# Altium schematic sheet Gain Control.SchDoc
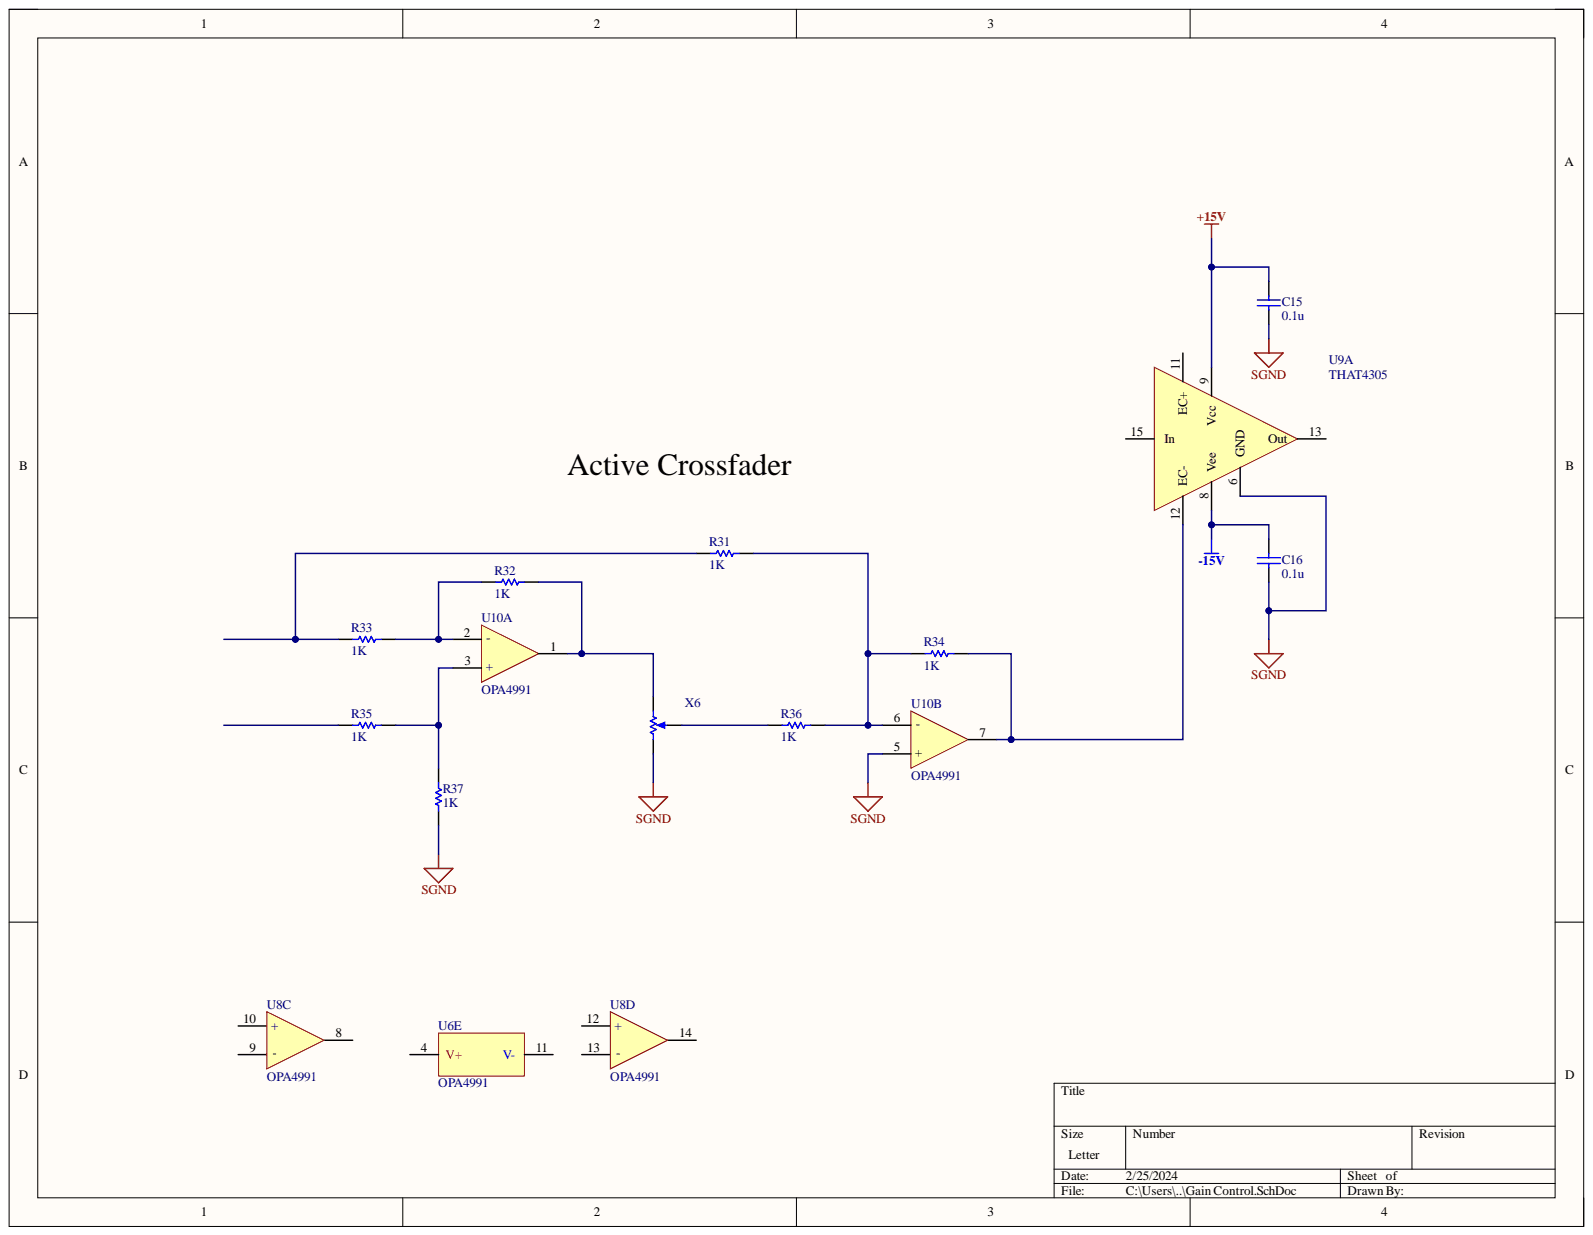
[diagram p0: Gain Control.SchDoc]

## Parts
C15  value 0.1u  (hidden comment: Capacitor)
C16  value 0.1u  (hidden comment: Capacitor)
R31  value 1K  (hidden comment: Resistor)
R32  value 1K  (hidden comment: Resistor)
R33  value 1K  (hidden comment: Resistor)
R34  value 1K  (hidden comment: Resistor)
R35  value 1K  (hidden comment: Resistor)
R36  value 1K  (hidden comment: Resistor)
R37  value 1K  (hidden comment: Resistor)
U6  OPA4991  [FP-D0014A-IPC_B]  (part E)
U8  OPA4991  [FP-D0014A-IPC_B]  (parts C,D)
U9  THAT4305  [QSOP-16]  (part A)
U10  OPA4991  [FP-D0014A-IPC_B]  (parts A,B)
X6  Potentiometer  (value not drawn)

## Nets
+15V: C15.2 U9.9(Vcc)
-15V: C16.2 U9.8(Vee)
SGND: C15.1 C16.1 R37.1 U9.6(GND) U10.5(+) X6.1
NetR31_1: R31.1 R33.1
NetR31_2: R31.2 R34.1 R36.2 U10.6(-)
NetR32_1: R32.1 R33.2 U10.2(-)
NetR32_2: R32.2 U10.1 X6.2
NetR34_2: R34.2 U9.12(EC-) U10.7
NetR35_2: R35.2 R37.2 U10.3(+)
NetR36_1: R36.1 X6.3
NetU9_13: U9.13(Out)

Not connected: R35 1; U6 4(V+) 11(V-); U8 8 9(-) 10(+) 12(+) 13(-) 14; U9 11(EC+) 15(In)

## Library data of the parts
C15 C16 = Capacitor: SimulationGenericComponent: True; Component Kind: Standard; Description: Capacitor; Library Name: Simulation Generic Components.IntLib; Simulation: C_Capacitor_Default
R31-R37 = Resistor: SimulationGenericComponent: True; TC1: 0; Component Kind: Standard; Description: Resistor; Library Name: Simulation Generic Components.IntLib; Simulation: R_Resistor_Default; TC2: 0
U6 U8 U10 = OPA4991IDR: 40-V, DUAL 4.5MHZ, RAIL-TO-RAIL; Component Kind: Standard; Library Name: DRC.SchLib
U9 = 4305Q16-U: Pre-trimmed Analog Engine® Dynamics Processor IC; Component Kind: Standard; Simulation: THAT4305; Library Name: DRC.SchLib
X6 = Potentiometer: position: 0.5; SimulationGenericComponent: True; value: 1k; Component Kind: Standard; Description: Potentiometer; Library Name: Simulation Generic Components.IntLib; Simulation: POT
